# Altium schematic sheet SPI.SchDoc(SPI)
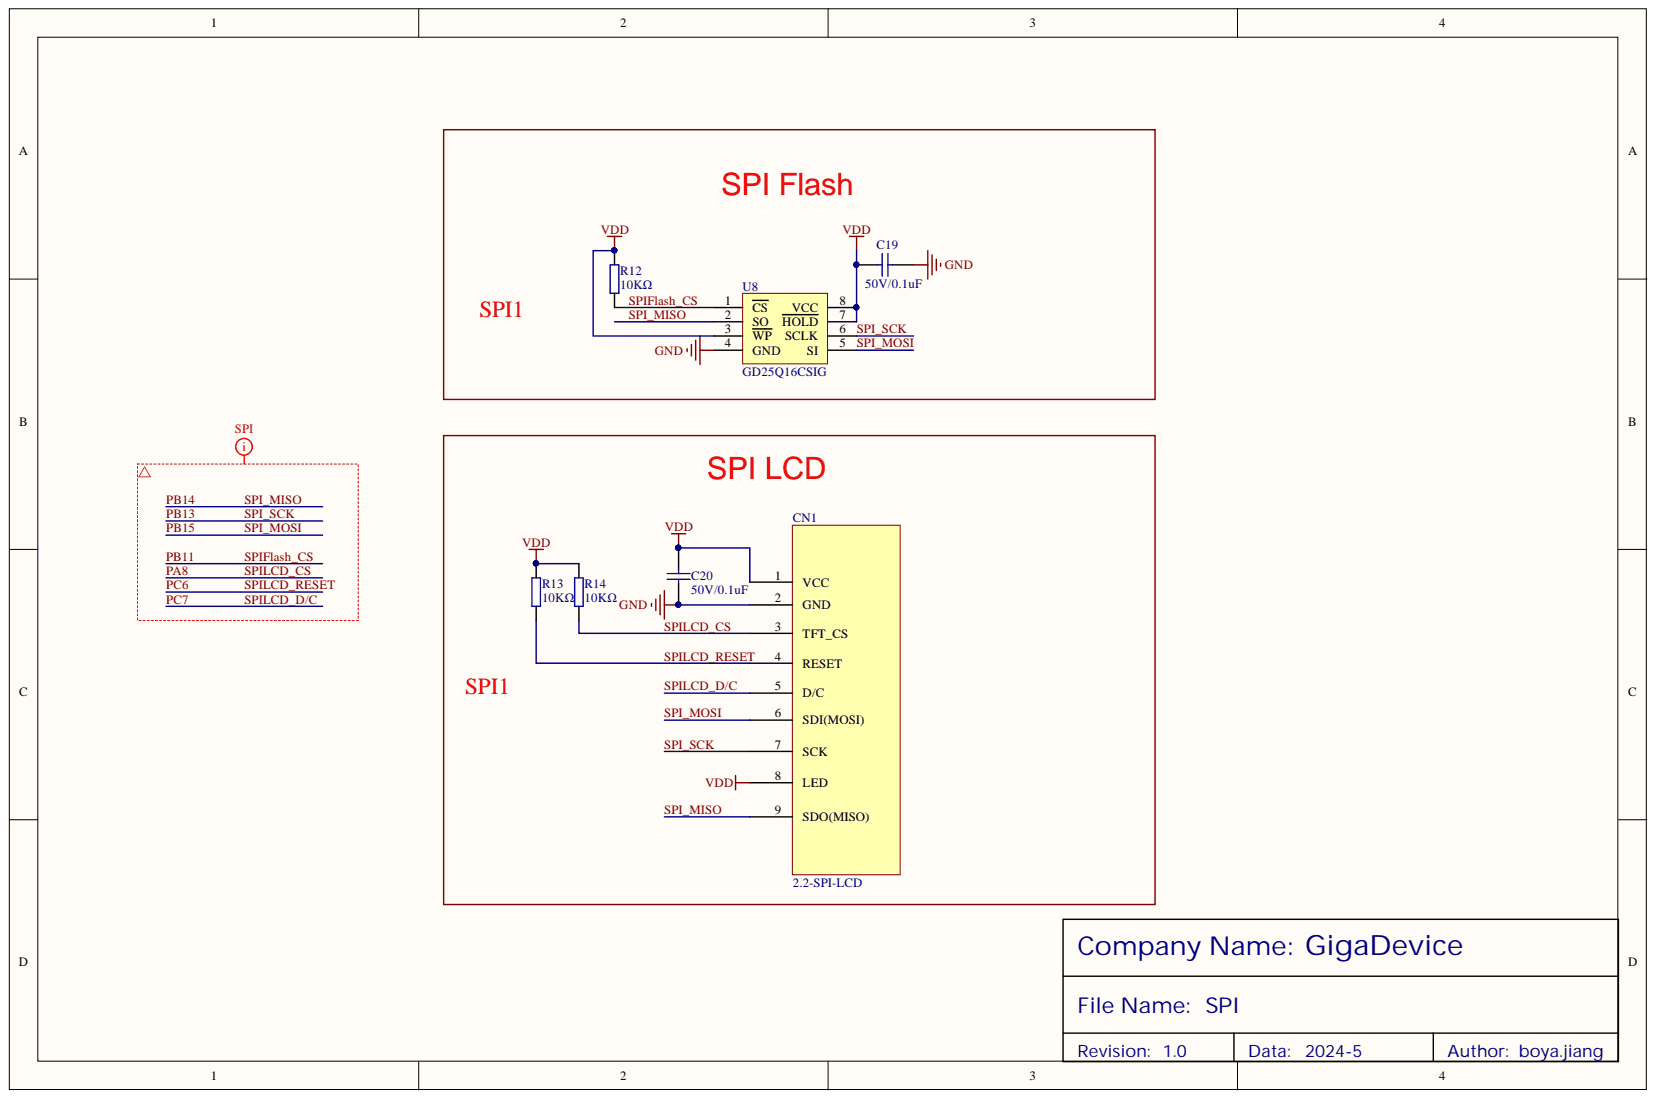
[diagram p0: SPI.SchDoc]

## Parts
C19  50V/0.1uF  [0603-C]
C20  50V/0.1uF  [0603-C]
CN1  2.2-SPI-LCD  [SIP-9]
R12  10KΩ  [0603-R]
R13  10KΩ  [0603-R]
R14  10KΩ  [0603-R]
U8  GD25Q16CSIG  [SOP-8(GD25Q16B)]

## Nets
GND: C19.2 C20.1 CN1.2(GND) U8.4(GND)
VDD: C19.1 C20.2 CN1.1(VCC) CN1.8(LED) R12.2 R13.2 R14.2 U8.3(WP) U8.7(HOLD) U8.8(VCC)
PA8: CN1.3(TFT_CS) R14.1
PB11: R12.1 U8.1(CS)
PB13: CN1.7(SCK) U8.6(SCLK)
PB14: CN1.9(SDO(MISO)) U8.2(SO)
PB15: CN1.6(SDI(MOSI)) U8.5(SI)
PC6: CN1.4(RESET) R13.1
PC7: CN1.5(D/C)
N00202:

## Library data of the parts
C19 C20 = 50V/0.1uF: 表贴电容; Component Kind: Standard; Library Name: KLTC-电容.SchLib
CN1 = SPI_LCD_Interface: 单排排母; Component Kind: Standard; Library Name: KLTC-按键及接插件.SchLib
R12-R14 = 10KΩ: 表贴电阻; Component Kind: Standard; Library Name: KLTC-电阻.SchLib
U8 = GD25Q40: 表贴SPI Flash; Component Kind: Standard; Library Name: xufei.SchLib
